# Altium schematic sheet 05_USB_FX3.SchDoc
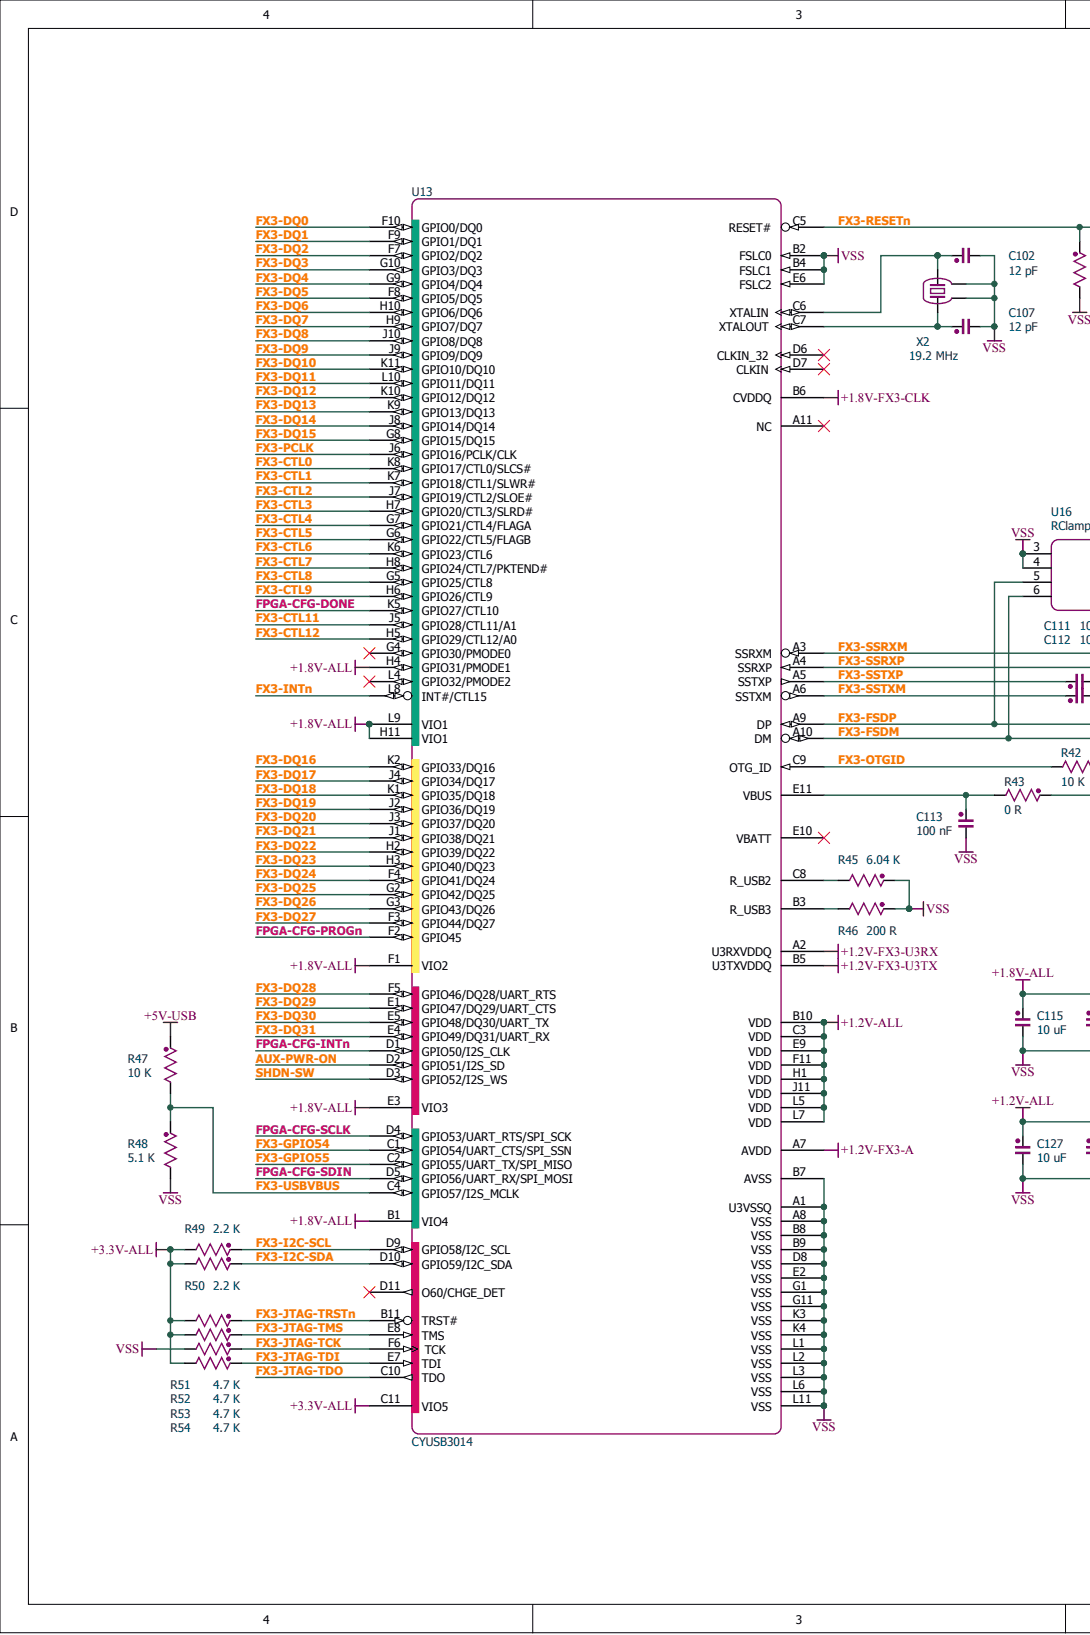
[diagram p0: 05_USB_FX3.SchDoc, left]
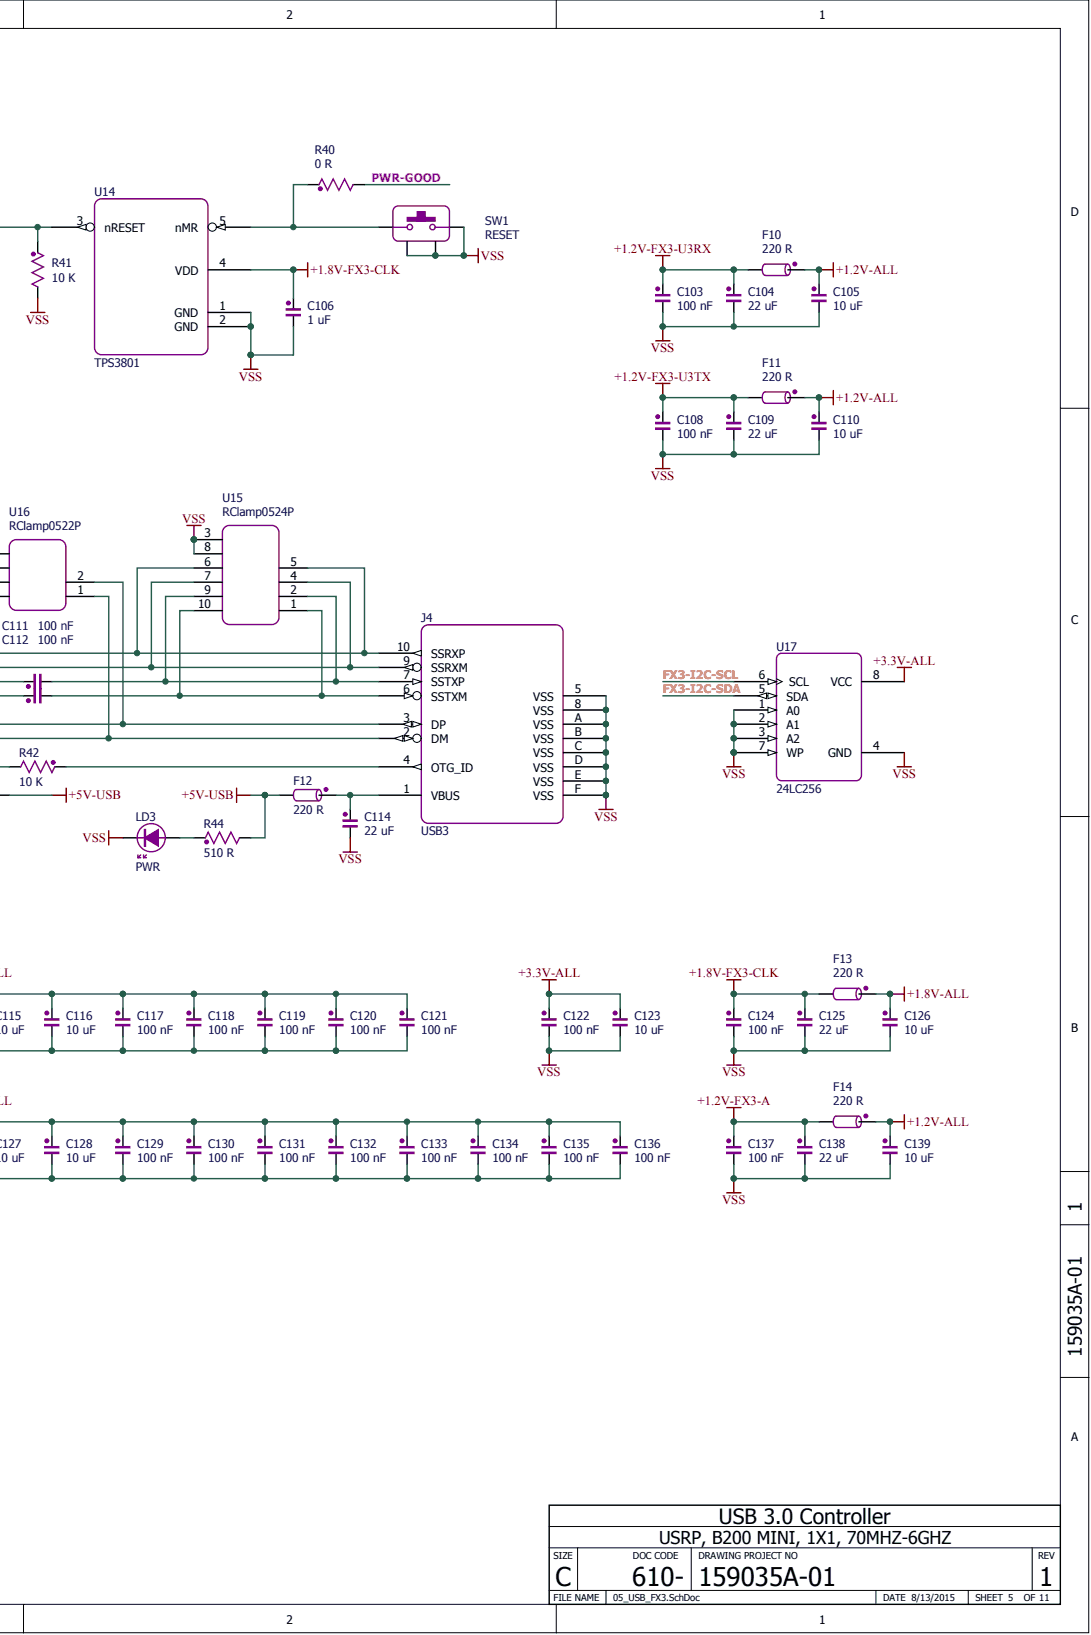
[diagram p1: 05_USB_FX3.SchDoc, right]

## Parts
C102  12 pF  [0201-CAP-RF]
C103  100 nF  [0201-CAP]
C104  22 uF  [0402-CAP]
C105  10 uF  [0402-CAP]
C106  1 uF  [0201-CAP]
C107  12 pF  [0201-CAP-RF]
C108  100 nF  [0201-CAP]
C109  22 uF  [0402-CAP]
C110  10 uF  [0402-CAP]
C111  100 nF  [0201-CAP]
C112  100 nF  [0201-CAP]
C113  100 nF  [0201-CAP]
C114  22 uF  [0805-CAP-HC]
C115  10 uF  [0402-CAP]
C116  10 uF  [0402-CAP]
C117  100 nF  [0201-CAP]
C118  100 nF  [0201-CAP]
C119  100 nF  [0201-CAP]
C120  100 nF  [0201-CAP]
C121  100 nF  [0201-CAP]
C122  100 nF  [0201-CAP]
C123  10 uF  [0402-CAP]
C124  100 nF  [0201-CAP]
C125  22 uF  [0402-CAP]
C126  10 uF  [0402-CAP]
C127  10 uF  [0402-CAP]
C128  10 uF  [0402-CAP]
C129  100 nF  [0201-CAP]
C130  100 nF  [0201-CAP]
C131  100 nF  [0201-CAP]
C132  100 nF  [0201-CAP]
C133  100 nF  [0201-CAP]
C134  100 nF  [0201-CAP]
C135  100 nF  [0201-CAP]
C136  100 nF  [0201-CAP]
C137  100 nF  [0201-CAP]
C138  22 uF  [0402-CAP]
C139  10 uF  [0402-CAP]
F10  220 R  [0402-BEAD]
F11  220 R  [0402-BEAD]
F12  220 R  [0805-BEAD]
F13  220 R  [0402-BEAD]
F14  220 R  [0402-BEAD]
J4  USB3  [GSB343K33HR]
LD3  PWR  [0603-LED]
R40  0 R  [0201-RES-Z]
R41  10 K  [0201-RES]
R42  10 K  [0402-RES]
R43  0 R  [0402-RES-Z]
R44  510 R  [0402-RES]
R45  6.04 K  [0201-RES]
R46  200 R  [0201-RES]
R47  10 K  [0402-RES]
R48  5.1 K  [0402-RES]
R49  2.2 K  [0201-RES]
R50  2.2 K  [0201-RES]
R51  4.7 K  [0201-RES]
R52  4.7 K  [0201-RES]
R53  4.7 K  [0201-RES]
R54  4.7 K  [0201-RES]
SW1  RESET  [SKSNLAE010]
U13  CYUSB3014  [FBGA-121-FX3]
U14  TPS3801  [TI-DCK-5]
U15  RClamp0524P  [RClamp0524P]
U16  RClamp0522P  [RClamp0522P]
U17  24LC256  [MSOP-8]
X2  19.2 MHz  [EPSON-FA-20H]

## Nets
+1.2V-ALL: C105.2 C110.2 C127.2 C128.2 C129.2 C130.2 C131.2 C132.2 C133.2 C134.2 C135.2 C136.2 C139.2 F10.2 F11.2 F14.2 U13.B10(VDD) U13.C3(VDD) U13.E9(VDD) U13.F11(VDD) U13.H1(VDD) U13.J11(VDD) U13.L5(VDD) U13.L7(VDD)
+1.2V-FX3-A: C137.2 C138.2 F14.1 U13.A7(AVDD)
+1.2V-FX3-U3RX: C103.2 C104.2 F10.1 U13.A2(U3RXVDDQ)
+1.2V-FX3-U3TX: C108.2 C109.2 F11.1 U13.B5(U3TXVDDQ)
+1.8V-ALL: C115.2 C116.2 C117.2 C118.2 C119.2 C120.2 C121.2 C126.2 F13.2 U13.B1(VIO4) U13.E3(VIO3) U13.F1(VIO2) U13.H4(GPIO31/PMODE1) U13.H11(VIO1) U13.L9(VIO1)
+1.8V-FX3-CLK: C106.2 C124.2 C125.2 F13.1 U13.B6(CVDDQ) U14.4(VDD)
+3.3V-ALL: C122.2 C123.2 R49.1 R50.1 R51.1 R52.1 R54.1 U13.C11(VIO5) U17.8(VCC)
+5V-USB: F12.1 R43.2 R44.1 R47.2
VSS: C102.1 C103.1 C104.1 C105.1 C106.1 C107.1 C108.1 C109.1 C110.1 C113.1 C114.1 C115.1 C116.1 C117.1 C118.1 C119.1 C120.1 C121.1 C122.1 C123.1 C124.1 C125.1 C126.1 C127.1 C128.1 C129.1 C130.1 C131.1 C132.1 C133.1 C134.1 C135.1 C136.1 C137.1 C138.1 C139.1 J4.5(VSS) J4.8(VSS) J4.A(VSS) J4.B(VSS) J4.C(VSS) J4.D(VSS) J4.E(VSS) J4.F(VSS) LD3.1 R41.1 R45.2 R46.2 R48.1 R53.1 SW1.1 SW1.A SW1.B U13.A1(U3VSSQ) U13.A8(VSS) U13.B2(FSLC0) U13.B4(FSLC1) U13.B7(AVSS) U13.B8(VSS) U13.B9(VSS) U13.D8(VSS) U13.E2(VSS) U13.E6(FSLC2) U13.G1(VSS) U13.G11(VSS) U13.K3(VSS) U13.K4(VSS) U13.L1(VSS) U13.L2(VSS) U13.L3(VSS) U13.L6(VSS) U13.L11(VSS) U14.1(GND) U14.2(GND) U15.3 U15.8 U16.3 U16.4 U17.1(A0) U17.2(A1) U17.3(A2) U17.4(GND) U17.7(WP) X2.2 X2.4
AUX-PWR-ON: U13.D2(GPIO51/I2S_SD)
FPGA-CFG-DONE: U13.K5(GPIO27/CTL10)
FPGA-CFG-INTN: U13.D1(GPIO50/I2S_CLK)
FPGA-CFG-PROGN: U13.F2(GPIO45)
FPGA-CFG-SCLK: U13.D4(GPIO53/UART_RTS/SPI_SCK)
FPGA-CFG-SDIN: U13.D5(GPIO56/UART_RX/SPI_MOSI)
FX3-CTL0: U13.K8(GPIO17/CTL0/SLCS#)
FX3-CTL1: U13.K7(GPIO18/CTL1/SLWR#)
FX3-CTL2: U13.J7(GPIO19/CTL2/SLOE#)
FX3-CTL3: U13.H7(GPIO20/CTL3/SLRD#)
FX3-CTL4: U13.G7(GPIO21/CTL4/FLAGA)
FX3-CTL5: U13.G6(GPIO22/CTL5/FLAGB)
FX3-CTL6: U13.K6(GPIO23/CTL6)
FX3-CTL7: U13.H8(GPIO24/CTL7/PKTEND#)
FX3-CTL8: U13.G5(GPIO25/CTL8)
FX3-CTL9: U13.H6(GPIO26/CTL9)
FX3-CTL11: U13.J5(GPIO28/CTL11/A1)
FX3-CTL12: U13.H5(GPIO29/CTL12/A0)
FX3-DQ0: U13.F10(GPIO0/DQ0)
FX3-DQ1: U13.F9(GPIO1/DQ1)
FX3-DQ2: U13.F7(GPIO2/DQ2)
FX3-DQ3: U13.G10(GPIO3/DQ3)
FX3-DQ4: U13.G9(GPIO4/DQ4)
FX3-DQ5: U13.F8(GPIO5/DQ5)
FX3-DQ6: U13.H10(GPIO6/DQ6)
FX3-DQ7: U13.H9(GPIO7/DQ7)
FX3-DQ8: U13.J10(GPIO8/DQ8)
FX3-DQ9: U13.J9(GPIO9/DQ9)
FX3-DQ10: U13.K11(GPIO10/DQ10)
FX3-DQ11: U13.L10(GPIO11/DQ11)
FX3-DQ12: U13.K10(GPIO12/DQ12)
FX3-DQ13: U13.K9(GPIO13/DQ13)
FX3-DQ14: U13.J8(GPIO14/DQ14)
FX3-DQ15: U13.G8(GPIO15/DQ15)
FX3-DQ16: U13.K2(GPIO33/DQ16)
FX3-DQ17: U13.J4(GPIO34/DQ17)
FX3-DQ18: U13.K1(GPIO35/DQ18)
FX3-DQ19: U13.J2(GPIO36/DQ19)
FX3-DQ20: U13.J3(GPIO37/DQ20)
FX3-DQ21: U13.J1(GPIO38/DQ21)
FX3-DQ22: U13.H2(GPIO39/DQ22)
FX3-DQ23: U13.H3(GPIO40/DQ23)
FX3-DQ24: U13.F4(GPIO41/DQ24)
FX3-DQ25: U13.G2(GPIO42/DQ25)
FX3-DQ26: U13.G3(GPIO43/DQ26)
FX3-DQ27: U13.F3(GPIO44/DQ27)
FX3-DQ28: U13.F5(GPIO46/DQ28/UART_RTS)
FX3-DQ29: U13.E1(GPIO47/DQ29/UART_CTS)
FX3-DQ30: U13.E5(GPIO48/DQ30/UART_TX)
FX3-DQ31: U13.E4(GPIO49/DQ31/UART_RX)
FX3-FSDM: J4.2(DM) U13.A10(DM) U16.1 U16.6
FX3-FSDP: J4.3(DP) U13.A9(DP) U16.2 U16.5
FX3-GPIO54: U13.C1(GPIO54/UART_CTS/SPI_SSN)
FX3-GPIO55: U13.C2(GPIO55/UART_TX/SPI_MISO)
FX3-I2C-SCL: R49.2 U13.D9(GPIO58/I2C_SCL) U17.6(SCL)
FX3-I2C-SDA: R50.2 U13.D10(GPIO59/I2C_SDA) U17.5(SDA)
FX3-INTN: U13.L8(INT#/CTL15)
FX3-JTAG-TCK: R53.2 U13.F6(TCK)
FX3-JTAG-TDI: R54.2 U13.E7(TDI)
FX3-JTAG-TDO: U13.C10(TDO)
FX3-JTAG-TMS: R52.2 U13.E8(TMS)
FX3-JTAG-TRSTN: R51.2 U13.B11(TRST#)
FX3-OTGID: R42.1 U13.C9(OTG_ID)
FX3-PCLK: U13.J6(GPIO16/PCLK/CLK)
FX3-RESETN: R41.2 U13.C5(RESET#) U14.3(nRESET)
FX3-SSRXM: J4.10(SSRXP) U13.A3(SSRXM) U15.5 U15.6
FX3-SSRXP: J4.9(SSRXM) U13.A4(SSRXP) U15.4 U15.7
FX3-SSTXM: C112.2 U13.A6(SSTXM)
FX3-SSTXP: C111.2 U13.A5(SSTXP)
FX3-USBVBUS: R47.1 R48.2 U13.C4(GPIO57/I2S_MCLK)
PWR-GOOD: R40.1
SHDN-SW: U13.D3(GPIO52/I2S_WS)
NetC102_2: C102.2 U13.C6(XTALIN) X2.3
NetC107_2: C107.2 U13.C7(XTALOUT) X2.1
NetC111_1: C111.1 J4.7(SSTXP) U15.2 U15.9
NetC112_1: C112.1 J4.6(SSTXM) U15.1 U15.10
NetC113_2: C113.2 R43.1 U13.E11(VBUS)
NetC114_2: C114.2 F12.2 J4.1(VBUS)
NetJ4_4: J4.4(OTG_ID) R42.2
NetLD3_2: LD3.2 R44.2
NetR40_2: R40.2 SW1.2 U14.5(nMR)
NetR45_1: R45.1 U13.C8(R_USB2)
NetR46_1: R46.1 U13.B3(R_USB3)

Not connected: U13 A11(NC) D6(CLKIN_32) D7(CLKIN) D11(O60/CHGE_DET) E10(VBATT) G4(GPIO30/PMODE0) L4(GPIO32/PMODE2)

## Library data of the parts
C102 C107 = CAP: CAP, 12pF, C0G, 25V, ±5%, 0201, PbF; PartNumber: C0201C0G250-120JNP; Designer: shyul; PCBMarker: Capacitor; PinCountHole: 0; PinCountSMD: 2; Vendor: VENKEL; Component Kind: Standard; SerialNumber: 0; Value: 12 pF; Version: 1.0; VersionDate: 04-27-2011; Library Name: Basc_1_Passive.IntLib; Publisher: Cowin
C103 C108 C111-C113 C117-C122 C124 C129-C137 = CAP: CAP,SM,0.1UF,16V,10%,CER,X5R,0201,H=.014IN; PartNumber: GRM033R61C104KE14D; Designer: shyul; PCBMarker: Capacitor; PinCountHole: 0; PinCountSMD: 2; SerialNumber: 717062-01; Vendor: MURATA; Component Kind: Standard; Value: 100 nF; Version: 1.0; VersionDate: 04-27-2011; Library Name: Basc_1_Passive.IntLib; Publisher: Cowin
C104 C109 C125 C138 = CAP: CAP, 22uF, X5R, 6.3V, ±20%, 0402, PbF; PartNumber: C0402X5R6R3-226MNP; Designer: shyul; PCBMarker: Capacitor; PinCountHole: 0; PinCountSMD: 2; Vendor: VENKEL; Component Kind: Standard; SerialNumber: 0; Value: 22 uF; Version: 1.0; VersionDate: 04-27-2011; Library Name: Basc_1_Passive.IntLib; Publisher: Cowin
C105 C110 C115 C116 C123 C126-C128 C139 = CAP: CAP, 10uF, X5R, 6.3V, ±20%, 0402, PbF; PartNumber: C0402X5R6R3-106MNP; Designer: shyul; PCBMarker: Capacitor; PinCountHole: 0; PinCountSMD: 2; Vendor: VENKEL; Component Kind: Standard; SerialNumber: 0; Value: 10 uF; Version: 1.0; VersionDate: 04-27-2011; Library Name: Basc_1_Passive.IntLib; Publisher: Cowin
C106 = CAP: CAP, 1uF, X5R, 6.3V, ±10%, 0402, PbF; PartNumber: C0402X7R6R3-105KNP; Designer: shyul; PCBMarker: Capacitor; PinCountHole: 0; PinCountSMD: 2; Vendor: VENKEL; Component Kind: Standard; SerialNumber: 0; Value: 1 uF; Version: 1.0; VersionDate: 04-27-2011; Library Name: Basc_1_Passive.IntLib; Publisher: Cowin
C114 = CAP: CAP, 22uF, X5R, 25V, ±20%, 0805, PbF; PartNumber: GRM21BR61E226ME44; Designer: shyul; PCBMarker: Capacitor; PinCountHole: 0; PinCountSMD: 2; Vendor: muRata; Component Kind: Standard; SerialNumber: 0; Value: 22 uF; Version: 1.0; VersionDate: 04-27-2011; Library Name: Basc_1_Passive.IntLib; Publisher: Cowin
F10 F11 F13 F14 = BEAD: BEAD, 220R, 700mA, PbF; PartNumber: BLM15EG221SN1; Designer: shyul; PinCountHole: 0; PinCountSMD: 2; Vendor: muRata; Component Kind: Standard; PCBMarker: Bead; SerialNumber: 0; Value: 220 R; Version: 1.0; VersionDate: 04-28-2011; Library Name: Basc_2_EMI_Protection.IntLib; Publisher: Cowin
F12 = BEAD: BEAD, 0805, 220R, 2000mA, PbF; PartNumber: FBC0805-221P; Designer: shyul; PinCountHole: 0; PinCountSMD: 2; Vendor: VENKEL; Component Kind: Standard; PCBMarker: Bead; SerialNumber: 0; Value: 220 R; Version: 1.0; VersionDate: 04-28-2011; Library Name: Basc_2_EMI_Protection.IntLib; Publisher: Cowin
J4 = GSB343K33HR: USB Connectors USB 3.0 Micro B R/A Recpt SMT Black; Designer: shyul; PCBMarker: USB-SS; PinCountHole: 0; PinCountSMD: 16; Vendor: Amphenol; Component Kind: Standard; PartNumber: GSB343K33HR; SerialNumber: 0; Value: USB3; Version: 1.0; VersionDate: 09-22-2014; Library Name: Argon.SchLib; Publisher: Shyu Lee
LD3 = LED: LED, Amber, 0603; PartNumber: TLMO1100-GS08; Designer: shyul; PinCountHole: 0; PinCountSMD: 2; Vendor: Vishay; Component Kind: Standard; PCBMarker: LED; SerialNumber: 0; Status: PWR; Value: AMBER; Version: 1.0; VersionDate: 04-27-2011; Library Name: Basc_4_Other.IntLib; Publisher: Cowin
R40 = RES: RES, 0R, 0.5A, 0201, PbF; PartNumber: RK73Z1HTTC; Designer: shyul; PCBMarker: Resistor; PinCountHole: 0; PinCountSMD: 2; Vendor: KOA; Component Kind: Standard; SerialNumber: 0; Value: 0 R; Version: 1.0; VersionDate: 04-27-2011; Library Name: Basc_1_Passive.IntLib; Publisher: Cowin
R41 = RES: RES, 10K, ±1%, 1/20W, 0201, PbF; PartNumber: CR0201-20W-1002FT; Designer: shyul; PCBMarker: Resistor; PinCountHole: 0; PinCountSMD: 2; Vendor: VENKEL; Component Kind: Standard; SerialNumber: 0; Value: 10 K; Version: 1.0; VersionDate: 04-27-2011; Library Name: Basc_1_Passive.IntLib; Publisher: Cowin
R42 R47 = RES: RES, 10K, ±5%, 1/16W, 0402, PbF; PartNumber: CR0402-16W-103JT; Designer: shyul; PCBMarker: Resistor; PinCountHole: 0; PinCountSMD: 2; Vendor: VENKEL; Component Kind: Standard; SerialNumber: 0; Value: 10 K; Version: 1.0; VersionDate: 04-27-2011; Library Name: Basc_1_Passive.IntLib; Publisher: Cowin
R43 = RES: RES, 0R, 1A, 0402, PbF; PartNumber: RK73Z1ETTP; Designer: shyul; PCBMarker: Resistor; PinCountHole: 0; PinCountSMD: 2; Vendor: KOA; Component Kind: Standard; SerialNumber: 0; Value: 0 R; Version: 1.0; VersionDate: 04-27-2011; Library Name: Basc_1_Passive.IntLib; Publisher: Cowin
R44 = RES: RES, 510R, ±5%, 1/16W, 0402, PbF; PartNumber: RK73B1ETTP511J; Designer: shyul; PCBMarker: Resistor; PinCountHole: 0; PinCountSMD: 2; Vendor: KOA; Component Kind: Standard; SerialNumber: 0; Value: 510 R; Version: 1.0; VersionDate: 04-27-2011; Library Name: Basc_1_Passive.IntLib; Publisher: Cowin
R45 = RES: RES, 6.04K, ±1%, 1/20W, 0201, PbF; PartNumber: RK73H1HTTC6041F; Designer: shyul; PCBMarker: Resistor; PinCountHole: 0; PinCountSMD: 2; Vendor: KOA; Component Kind: Standard; SerialNumber: 0; Value: 6.04 K; Version: 1.0; VersionDate: 04-27-2011; Library Name: Basc_1_Passive.IntLib; Publisher: Cowin
R46 = RES: RES, 200R, ±1%, 1/20W, 0201, PbF; PartNumber: RK73H1HTTC2000F; Designer: shyul; PCBMarker: Resistor; PinCountHole: 0; PinCountSMD: 2; Vendor: KOA; Component Kind: Standard; SerialNumber: 0; Value: 200 R; Version: 1.0; VersionDate: 04-27-2011; Library Name: Basc_1_Passive.IntLib; Publisher: Cowin
R48 = RES: RES, 5.1K, ±5%, 1/16W, 0402, PbF; PartNumber: RK73B1ETTP512J; Designer: shyul; PCBMarker: Resistor; PinCountHole: 0; PinCountSMD: 2; Vendor: KOA; Component Kind: Standard; SerialNumber: 0; Value: 5.1 K; Version: 1.0; VersionDate: 04-27-2011; Library Name: Basc_1_Passive.IntLib; Publisher: Cowin
R49 R50 = RES: RES, 2.2K, ±5%, 1/20W, 0201, PbF; PartNumber: CR0201-20W-222JT; Designer: shyul; PCBMarker: Resistor; PinCountHole: 0; PinCountSMD: 2; Vendor: VENKEL; Component Kind: Standard; SerialNumber: 0; Value: 2.2 K; Version: 1.0; VersionDate: 04-27-2011; Library Name: Basc_1_Passive.IntLib; Publisher: Cowin
R51-R54 = RES: RES, 4.7K, ±5%, 1/20W, 0201, PbF; PartNumber: CR0201-20W-472JT; Designer: shyul; PCBMarker: Resistor; PinCountHole: 0; PinCountSMD: 2; Vendor: VENKEL; Component Kind: Standard; SerialNumber: 0; Value: 4.7 K; Version: 1.0; VersionDate: 04-27-2011; Library Name: Basc_1_Passive.IntLib; Publisher: Cowin
SW1 = SKSNLAE010: Side Push Mid-mount Type with 1.35mm Height (Surface Mount) SKSN Series; Designer: shyul; PCBMarker: SW; PinCountHole: 0; PinCountSMD: 4; Vendor: ALPS; Component Kind: Standard; PartNumber: SKSNLAE010; SerialNumber: 0; Status: RESET; Value: SKSN; Version: 1.0; VersionDate: 12-05-2014; Library Name: Argon.SchLib; Publisher: Cowin
U13 = CYUSB3014: EZ-USB FX3 SuperSpeed USB Controller; PartNumber: CYUSB3014-BZXI; Designer: shyul; PCBMarker: USB-SS; PinCountHole: 0; PinCountSMD: 121; Vendor: Cypress; Component Kind: Standard; SerialNumber: 0; Value: CYUSB3014; Version: 1.0; VersionDate: 07-22-2014; Library Name: Argon.SchLib; Publisher: Shyu Lee
U14 = TPS3801: ULTRA-SMALL SUPPLY VOLTAGE SUPERVISORS; PartNumber: TPS3801E18DCK; Designer: shyul; PCBMarker: Reset; PinCountHole: 0; PinCountSMD: 5; Vendor: TI; Component Kind: Standard; SerialNumber: 0; Value: TPS3801; Version: 1.0; VersionDate: 11-11-2014; Library Name: Argon.SchLib; Publisher: Shyu Lee
U15 = RClamp0524P: Ultra Low Capacitance TVS Arrays; Designer: shyul; PCBMarker: USB-SS; PinCountHole: 0; PinCountSMD: 10; Vendor: SEMTECH; Component Kind: Standard; PartNumber: RClamp0524P; SerialNumber: 0; Value: RClamp0524P; Version: 1.0; VersionDate: 09-22-2014; Library Name: Argon.SchLib; Publisher: Shyu Lee
U16 = RClamp0522P: Ultra Low Capacitance TVS Arrays; Designer: shyul; PCBMarker: USB-SS; PinCountHole: 0; PinCountSMD: 6; Vendor: SEMTECH; Component Kind: Standard; PartNumber: RClamp0522P; SerialNumber: 0; Value: RClamp0522P; Version: 1.0; VersionDate: 09-22-2014; Library Name: Argon.SchLib; Publisher: Shyu Lee
U17 = 24LC024: Generic 24 Series I2C ROM; PartNumber: 24LC256-I/MS; Designer: shyul; PCBMarker: I2C ROM; PinCountHole: 0; PinCountSMD: 8; Vendor: Microchip; Component Kind: Standard; SerialNumber: 0; Value: 24LC256; Version: 1.0; VersionDate: 05-08-2011; Library Name: UBX.SchLib; Publisher: Cowin
X2 = FA-20H: 19.2MHz Crystal; PartNumber: ECS-192-8-36CKM; Designer: shyul; PinCountHole: 0; PinCountSMD: 4; Vendor: ECS; Component Kind: Standard; PCBMarker: Crystal; SerialNumber: 0; Value: 19.2 MHz; Version: 1.0; VersionDate: 09-26-2014; Library Name: Argon.SchLib; Publisher: Cowin
